# Altium schematic sheet 03 FPGA_POWER.SchDoc
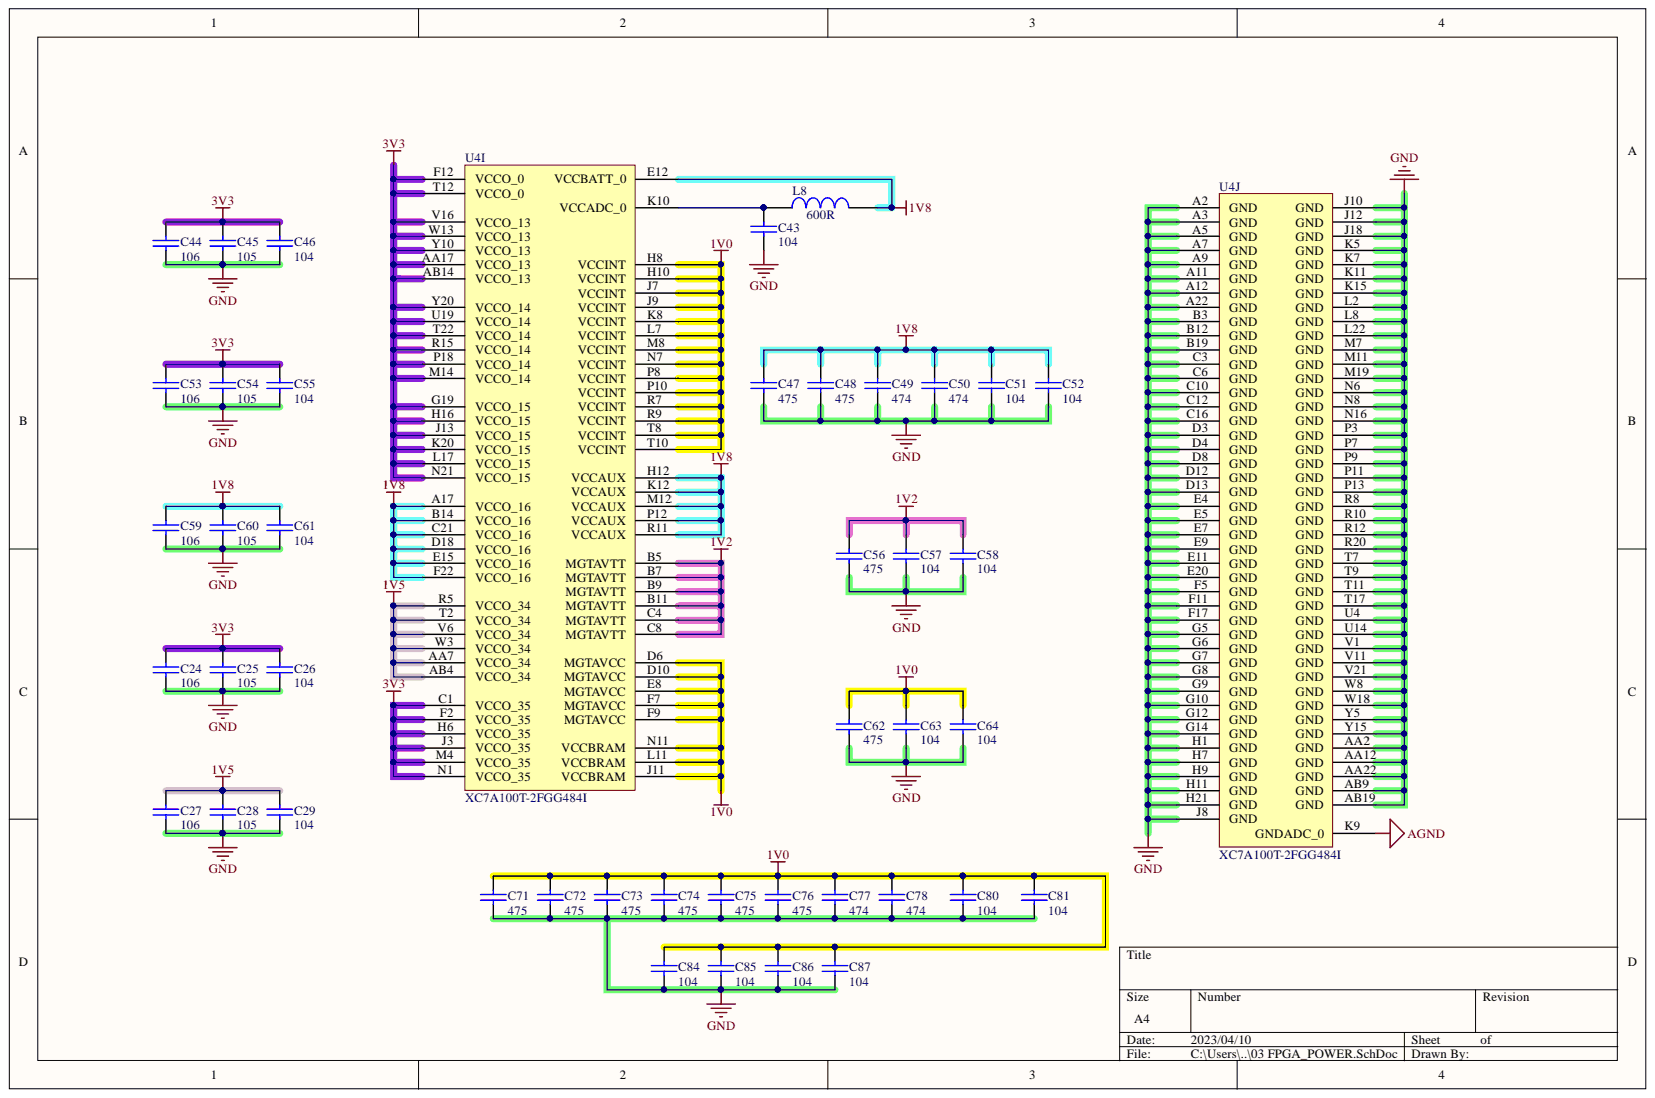
[diagram p0: 03 FPGA_POWER.SchDoc]

## Parts
C24  106  [0402C]
C25  105  [0402C]
C26  104  [0402C]
C27  106  [0402C]
C28  105  [0402C]
C29  104  [0402C]
C43  104  [0402C]
C44  106  [0402C]
C45  105  [0402C]
C46  104  [0402C]
C47  475  [0402C]
C48  475  [0402C]
C49  474  [0402C]
C50  474  [0402C]
C51  104  [0402C]
C52  104  [0402C]
C53  106  [0402C]
C54  105  [0402C]
C55  104  [0402C]
C56  475  [0402C]
C57  104  [0402C]
C58  104  [0402C]
C59  106  [0402C]
C60  105  [0402C]
C61  104  [0402C]
C62  475  [0402C]
C63  104  [0402C]
C64  104  [0402C]
C71  475  [0402C]
C72  475  [0402C]
C73  475  [0402C]
C74  475  [0402C]
C75  475  [0402C]
C76  475  [0402C]
C77  474  [0402C]
C78  474  [0402C]
C80  104  [0402C]
C81  104  [0402C]
C84  104  [0402C]
C85  104  [0402C]
C86  104  [0402C]
C87  104  [0402C]
L8  600R  [0603L]
U4  XC7A100T-2FGG484I  [FGG484]  (parts I,J)

## Nets
1V0: C62.2 C63.2 C64.2 C71.2 C72.2 C73.2 C74.2 C75.2 C76.2 C77.2 C78.2 C80.2 C81.2 C84.2 C85.2 C86.2 C87.2 U4.D6(MGTAVCC) U4.D10(MGTAVCC) U4.E8(MGTAVCC) U4.F7(MGTAVCC) U4.F9(MGTAVCC) U4.H8(VCCINT) U4.H10(VCCINT) U4.J7(VCCINT) U4.J9(VCCINT) U4.J11(VCCBRAM) U4.K8(VCCINT) U4.L7(VCCINT) U4.L11(VCCBRAM) U4.M8(VCCINT) U4.N7(VCCINT) U4.N11(VCCBRAM) U4.P8(VCCINT) U4.P10(VCCINT) U4.R7(VCCINT) U4.R9(VCCINT) U4.T8(VCCINT) U4.T10(VCCINT)
1V2: C56.2 C57.2 C58.2 U4.B5(MGTAVTT) U4.B7(MGTAVTT) U4.B9(MGTAVTT) U4.B11(MGTAVTT) U4.C4(MGTAVTT) U4.C8(MGTAVTT)
1V5: C27.2 C28.2 C29.2 U4.AA7(VCCO_34) U4.AB4(VCCO_34) U4.R5(VCCO_34) U4.T2(VCCO_34) U4.V6(VCCO_34) U4.W3(VCCO_34)
1V8: C47.2 C48.2 C49.2 C50.2 C51.2 C52.2 C59.2 C60.2 C61.2 L8.2 U4.A17(VCCO_16) U4.B14(VCCO_16) U4.C21(VCCO_16) U4.D18(VCCO_16) U4.E12(VCCBATT_0) U4.E15(VCCO_16) U4.F22(VCCO_16) U4.H12(VCCAUX) U4.K12(VCCAUX) U4.M12(VCCAUX) U4.P12(VCCAUX) U4.R11(VCCAUX)
3V3: C24.2 C25.2 C26.2 C44.2 C45.2 C46.2 C53.2 C54.2 C55.2 U4.AA17(VCCO_13) U4.AB14(VCCO_13) U4.C1(VCCO_35) U4.F2(VCCO_35) U4.F12(VCCO_0) U4.G19(VCCO_15) U4.H6(VCCO_35) U4.H16(VCCO_15) U4.J3(VCCO_35) U4.J13(VCCO_15) U4.K20(VCCO_15) U4.L17(VCCO_15) U4.M4(VCCO_35) U4.M14(VCCO_14) U4.N1(VCCO_35) U4.N21(VCCO_15) U4.P18(VCCO_14) U4.R15(VCCO_14) U4.T12(VCCO_0) U4.T22(VCCO_14) U4.U19(VCCO_14) U4.V16(VCCO_13) U4.W13(VCCO_13) U4.Y10(VCCO_13) U4.Y20(VCCO_14)
AGND: U4.K9(GNDADC_0)
GND: C24.1 C25.1 C26.1 C27.1 C28.1 C29.1 C43.1 C44.1 C45.1 C46.1 C47.1 C48.1 C49.1 C50.1 C51.1 C52.1 C53.1 C54.1 C55.1 C56.1 C57.1 C58.1 C59.1 C60.1 C61.1 C62.1 C63.1 C64.1 C71.1 C72.1 C73.1 C74.1 C75.1 C76.1 C77.1 C78.1 C80.1 C81.1 C84.1 C85.1 C86.1 C87.1 U4.A2(GND) U4.A3(GND) U4.A5(GND) U4.A7(GND) U4.A9(GND) U4.A11(GND) U4.A12(GND) U4.A22(GND) U4.AA2(GND) U4.AA12(GND) U4.AA22(GND) U4.AB9(GND) U4.AB19(GND) U4.B3(GND) U4.B12(GND) U4.B19(GND) U4.C3(GND) U4.C6(GND) U4.C10(GND) U4.C12(GND) U4.C16(GND) U4.D3(GND) U4.D4(GND) U4.D8(GND) U4.D12(GND) U4.D13(GND) U4.E4(GND) U4.E5(GND) U4.E7(GND) U4.E9(GND) U4.E11(GND) U4.E20(GND) U4.F5(GND) U4.F11(GND) U4.F17(GND) U4.G5(GND) U4.G6(GND) U4.G7(GND) U4.G8(GND) U4.G9(GND) U4.G10(GND) U4.G12(GND) U4.G14(GND) U4.H1(GND) U4.H7(GND) U4.H9(GND) U4.H11(GND) U4.H21(GND) U4.J8(GND) U4.J10(GND) U4.J12(GND) U4.J18(GND) U4.K5(GND) U4.K7(GND) U4.K11(GND) U4.K15(GND) U4.L2(GND) U4.L8(GND) U4.L22(GND) U4.M7(GND) U4.M11(GND) U4.M19(GND) U4.N6(GND) U4.N8(GND) U4.N16(GND) U4.P3(GND) U4.P7(GND) U4.P9(GND) U4.P11(GND) U4.P13(GND) U4.R8(GND) U4.R10(GND) U4.R12(GND) U4.R20(GND) U4.T7(GND) U4.T9(GND) U4.T11(GND) U4.T17(GND) U4.U4(GND) U4.U14(GND) U4.V1(GND) U4.V11(GND) U4.V21(GND) U4.W8(GND) U4.W18(GND) U4.Y5(GND) U4.Y15(GND)
NetC43_2: C43.2 L8.1 U4.K10(VCCADC_0)

## Library data of the parts
C24-C29 C43-C64 C71-C78 C80 C81 C84-C87 = CAP: 无极性电容; Component Kind: Standard; Library Name: 电容.IntLib
L8 = INDUCTOR: Component Kind: Standard; Description: INDUCTOR; Library Name: 电感.IntLib
U4 = XC7A100T-2FGG484I: Artix-7 FPGA, 285 User I/Os, 4 GTP, 484-Ball BGA, Speed Grade 2, Industrial Grade, Pb-Free; Manufacturer: Xilinx; Block RAM Blocks (18Kb): 270; Block RAM Blocks (36Kb): 135; Block RAM Blocks (Max Kb): 4860; CLBs (Slices): 15850; Clock Mgmt Tiles (CMTs): 6; DSP48E1 Slices: 240; Logic Cells: 101440; Max User I/O: 300; Speed Grade: -2; CLBs (Max Distributed RAM Kb): 1188; Code_JEDEC: MS-034-AAJ-1; Component Kind: Standard; ComponentLink1Description: Manufacturer URL; ComponentLink1URL: http://www.xilinx.com/; ComponentLink2Description: Datasheet; ComponentLink2URL: http://www.xilinx.com/support/documentation/data_sheets/ds180_7Series_Overview.pdf; ComponentLink3Description: Package Specification; ComponentLink3URL: http://www.xilinx.com/support/documentation/user_guides/ug475_7Series_Pkg_Pinout.pdf; ComponentLink4Description: DC and Switching Characteristics; ComponentLink4URL: http://www.xilinx.com/support/documentation/data_sheets/ds181_Artix_7_Data_Sheet.pdf; ComponentLink5Description: Package File; ComponentLink5URL: http://www.xilinx.com/support/packagefiles/a7packages/xc7a100tfgg484pkg.txt; ComponentLink6Description: Packaging and Pinout; ComponentLink6URL: http://www.xilinx.com/support/documentation/user_guides/ug475_7Series_Pkg_Pinout.pdf; DatasheetVersion: v1.12; Generic Part Number: XC7A100T; Library Name: Xilinx Artix-7.IntLib; Mounting Technology: Surface Mount; NexusDeviceID: XC7A100T-2FGG484I; PackageDescription: Wire-Bond Fine-Pitch BGA, Body 23 x 23 mm, 1.0 mm Pitch; PackageReference: FGG484; PackageVersion: Rev 1.8; PartNumber: XC7A100T-2FGG484I; RoHS: Pb-Free; Supplier 1: Digi-Key; Supplier Part Number 1: 122-1879-ND
